# Altium schematic sheet LPC55S69_MINI.SchDoc(LPC55S69_MINI)
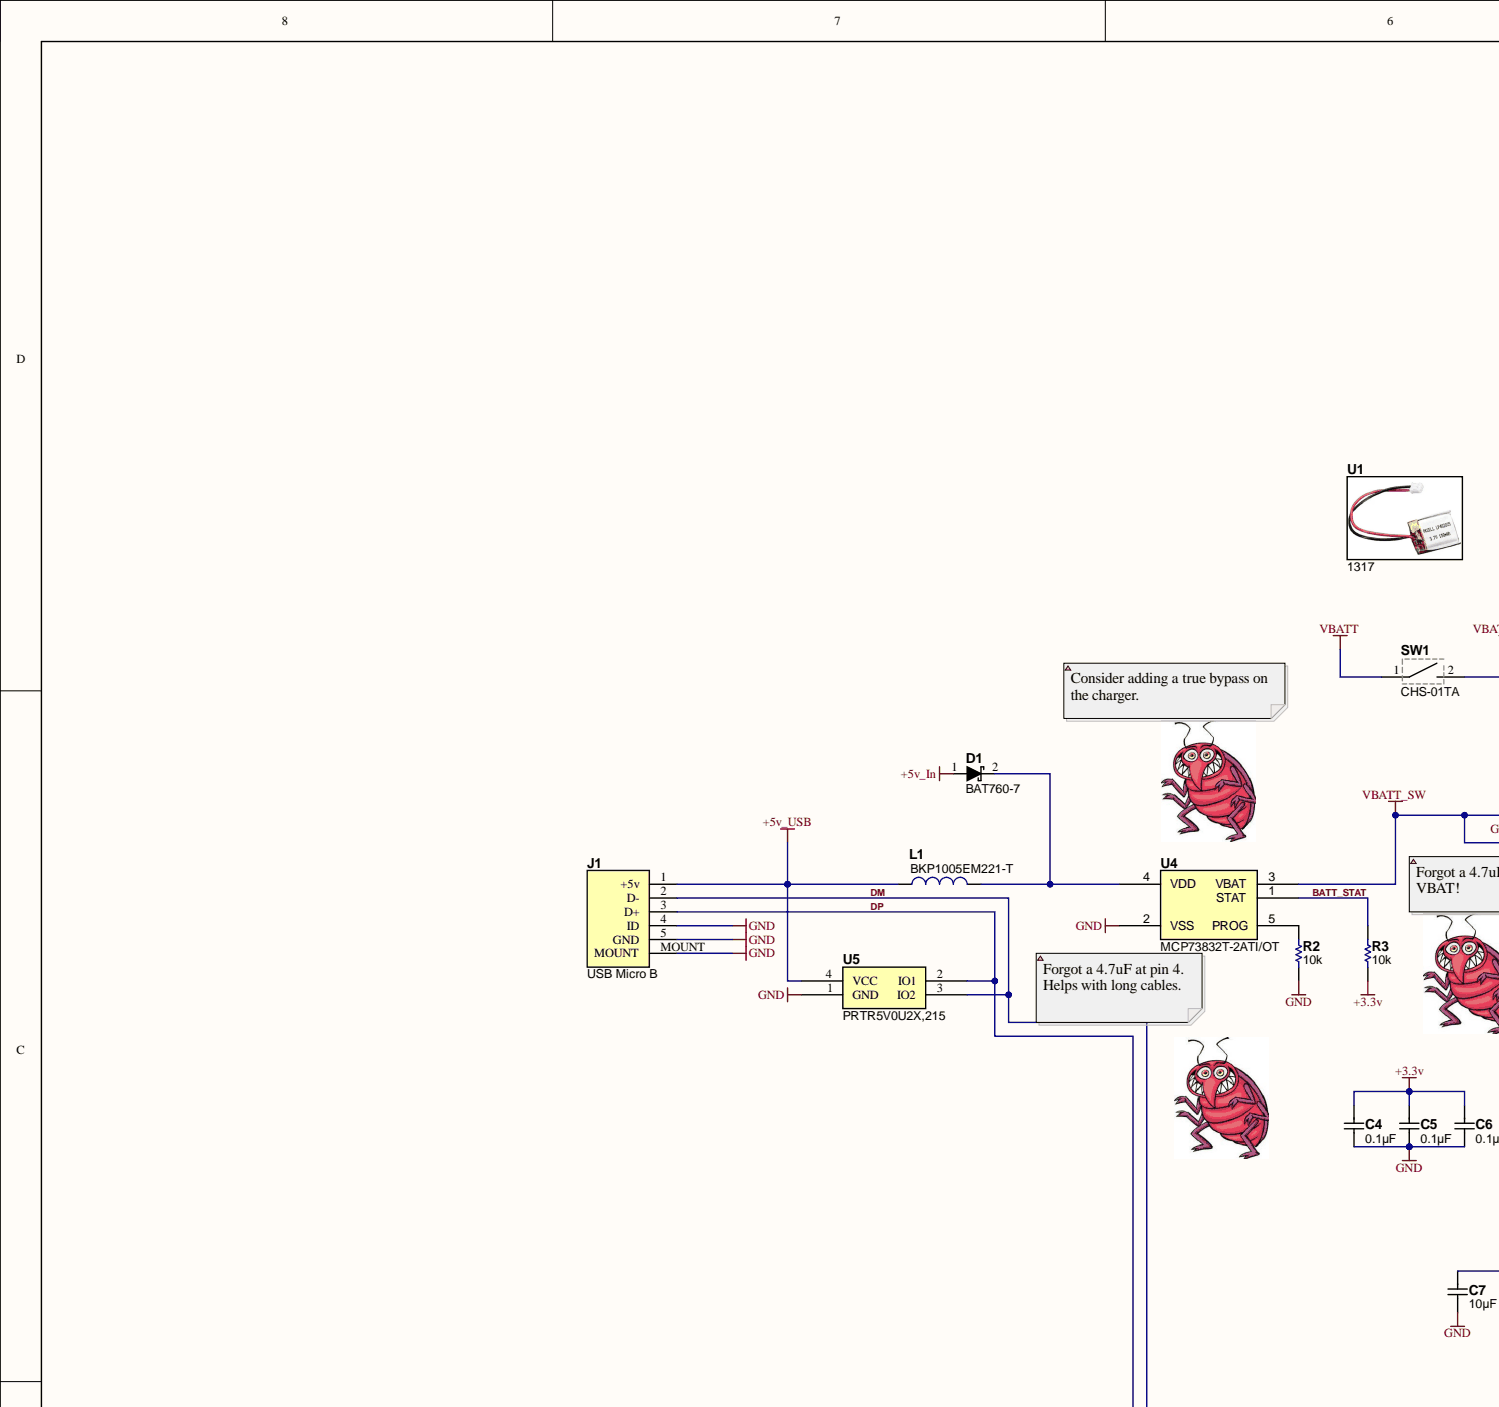
[diagram p0: LPC55S69_MINI.SchDoc, top left]
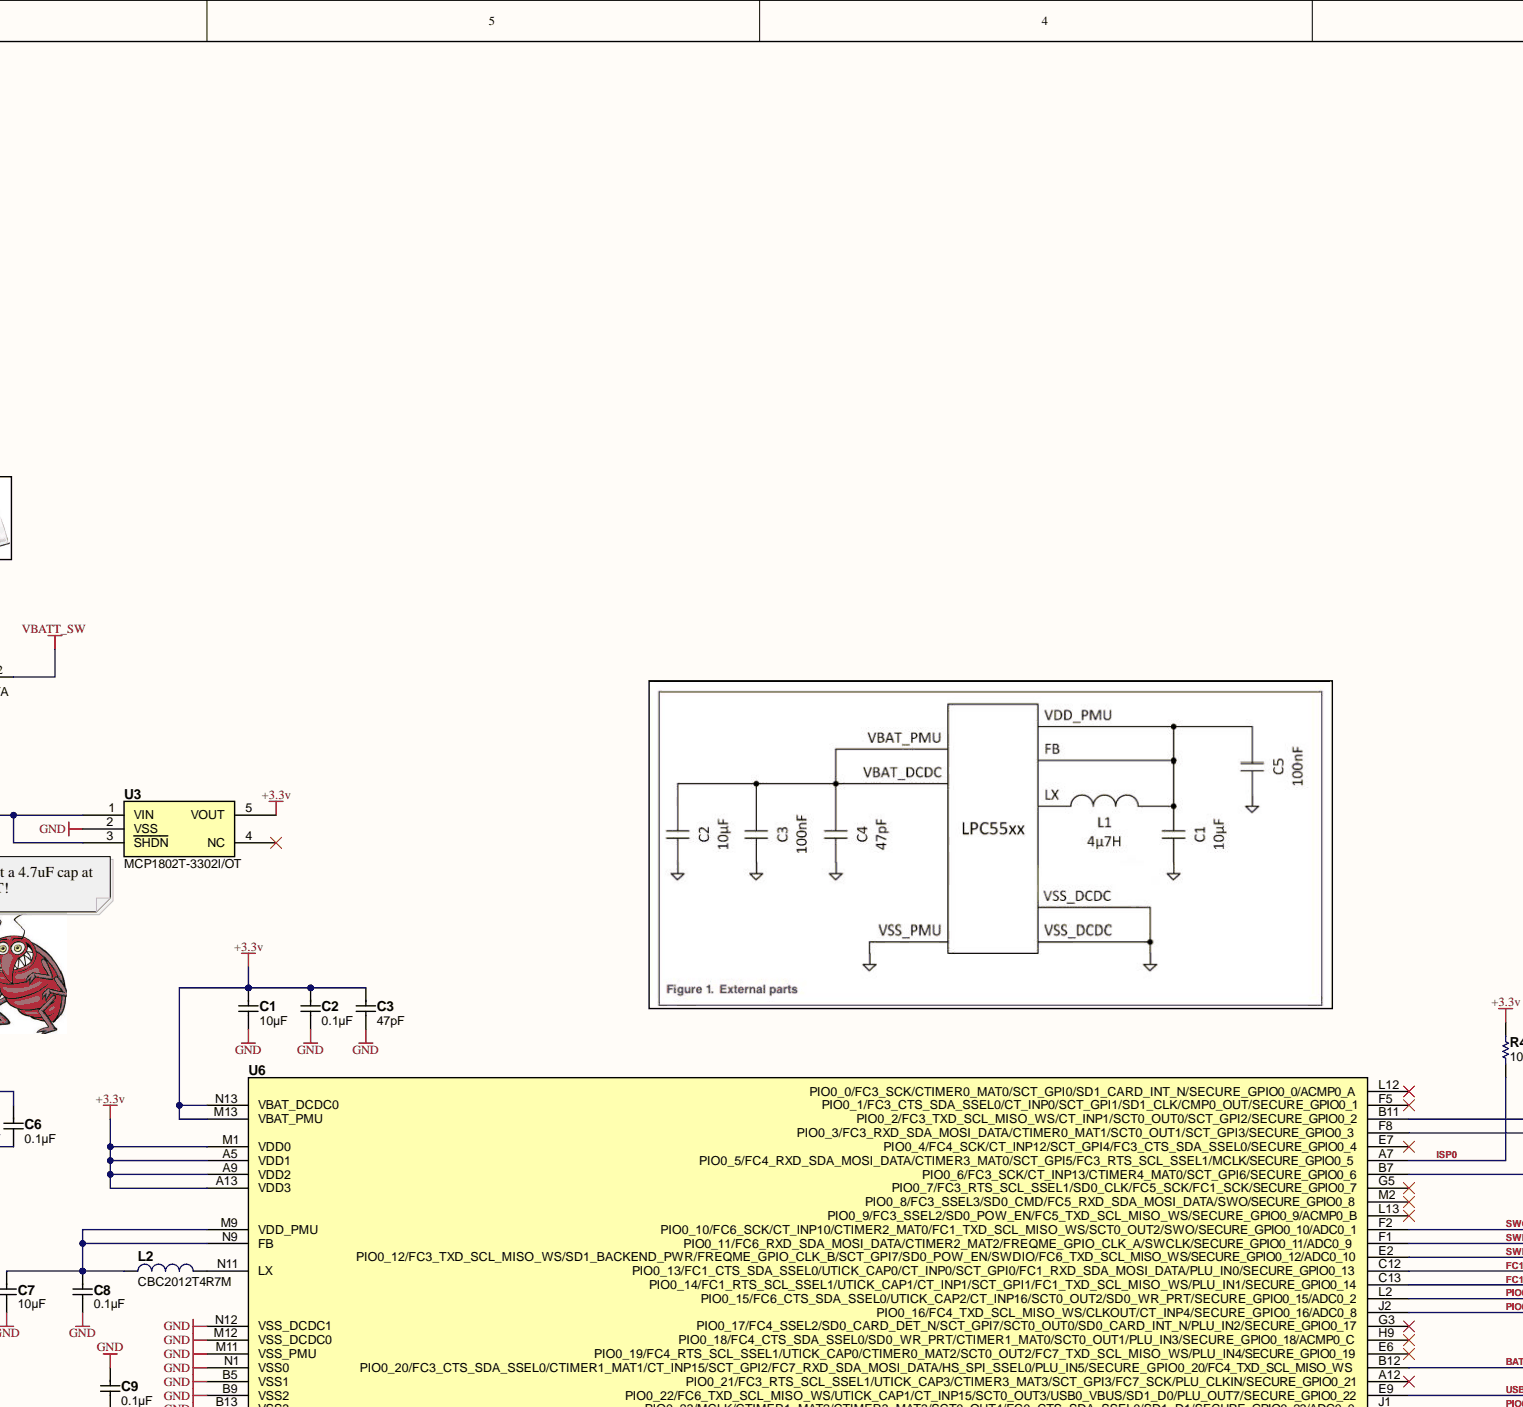
[diagram p1: LPC55S69_MINI.SchDoc, top centre]
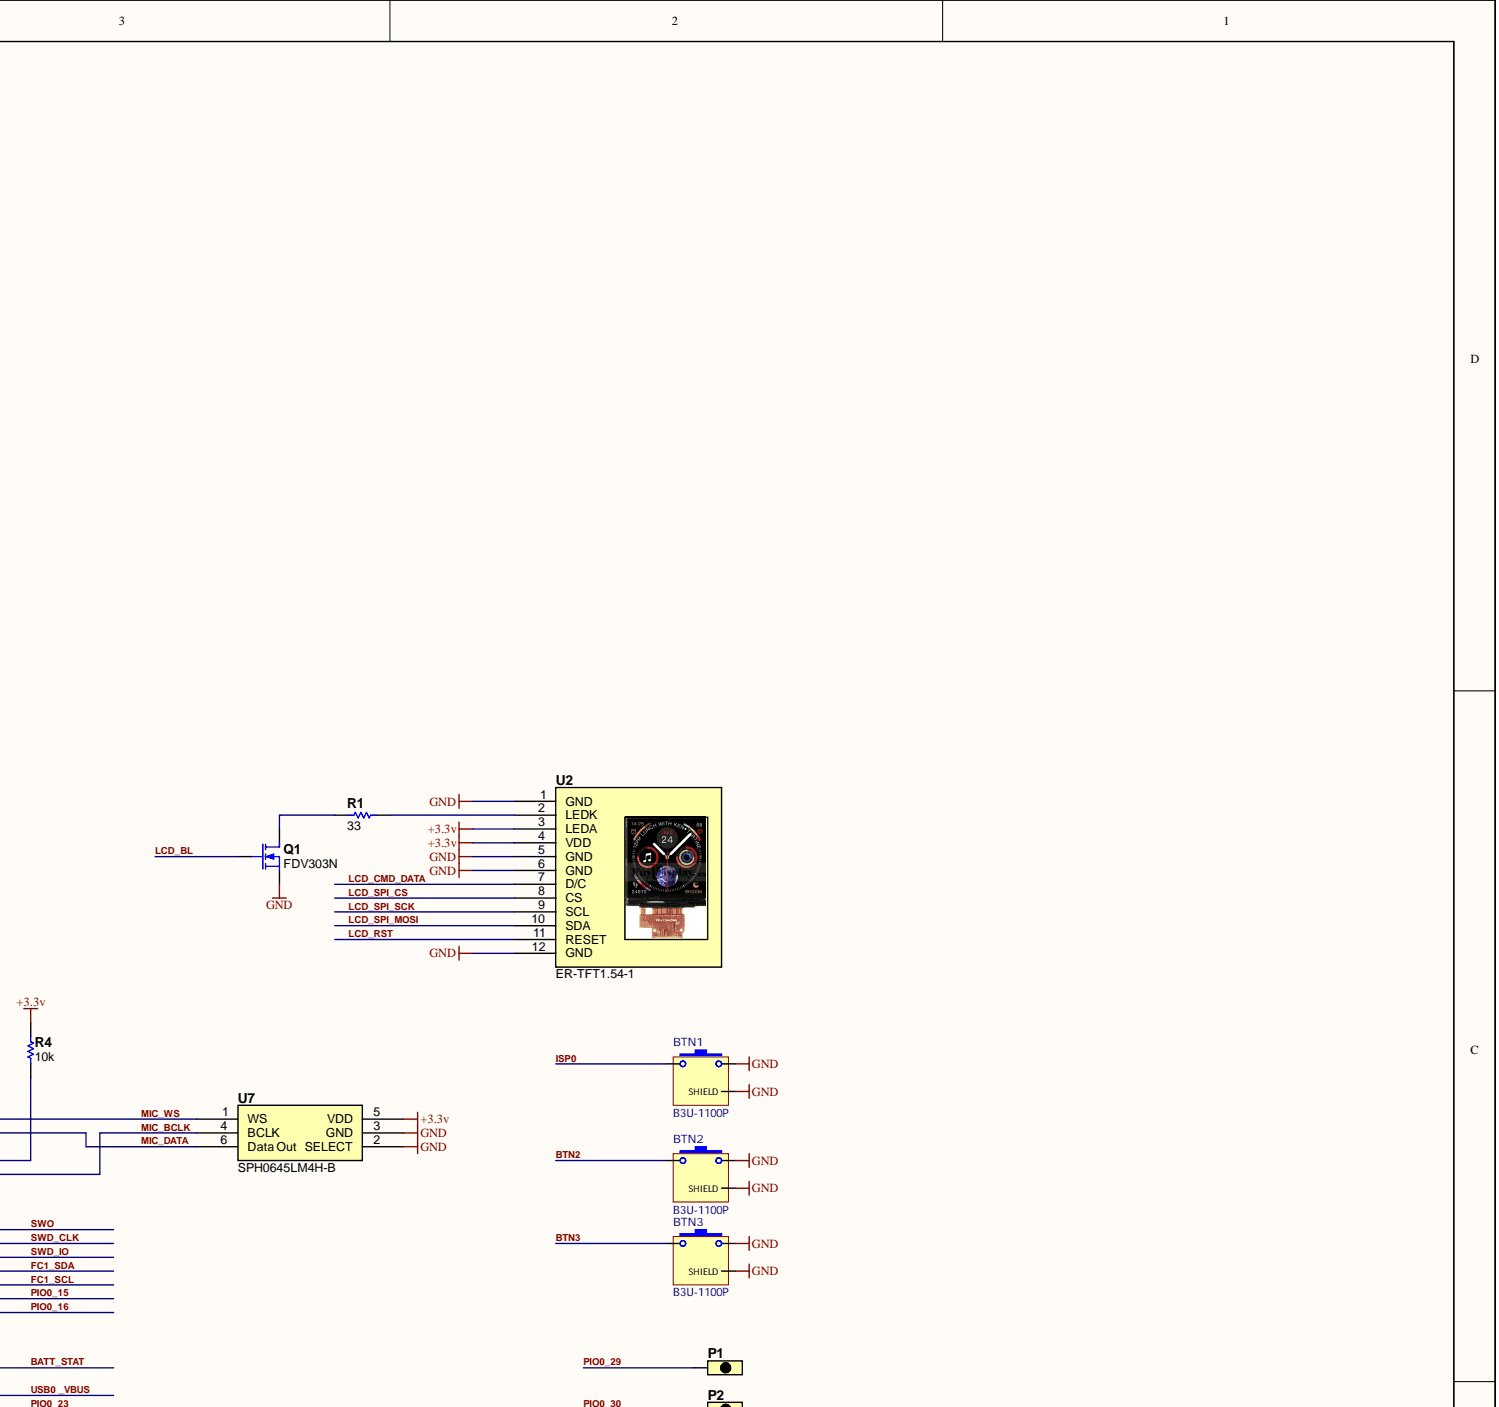
[diagram p2: LPC55S69_MINI.SchDoc, top right]
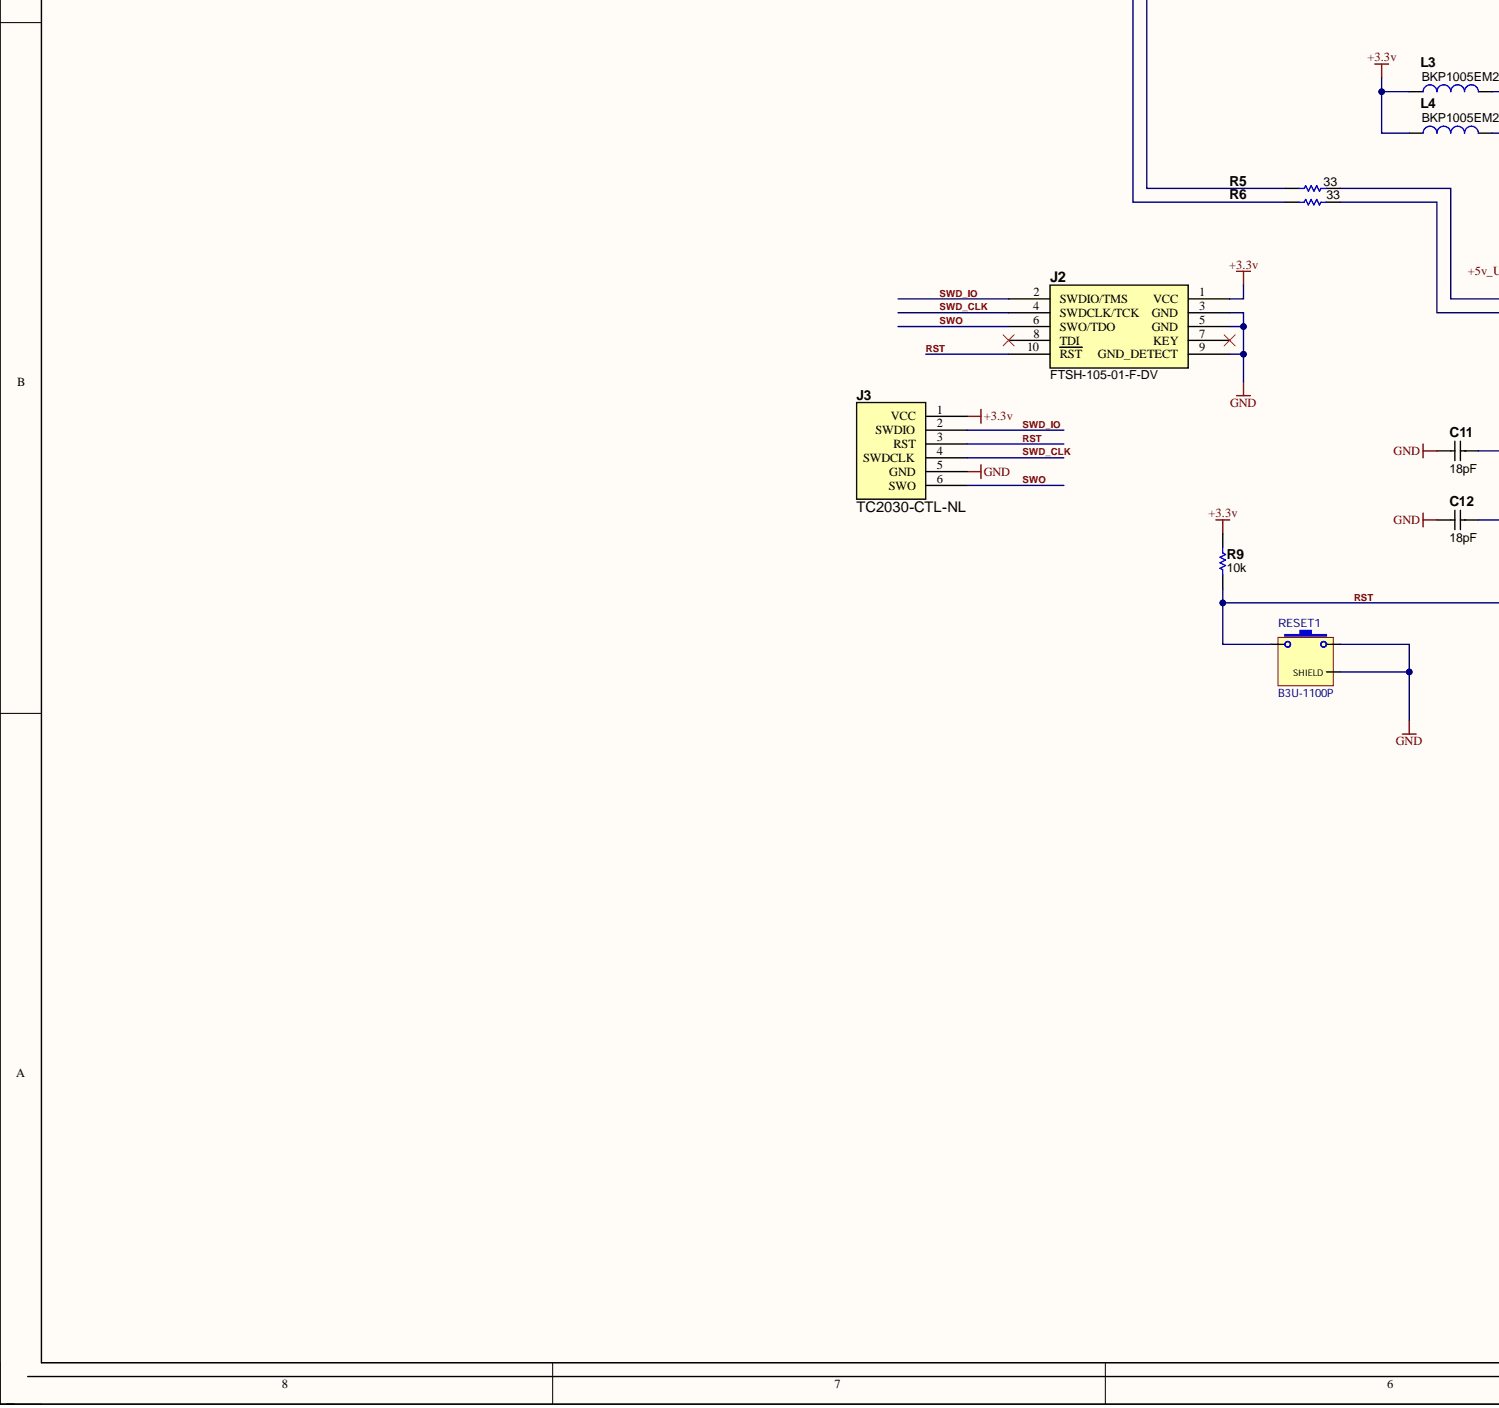
[diagram p3: LPC55S69_MINI.SchDoc, bottom left]
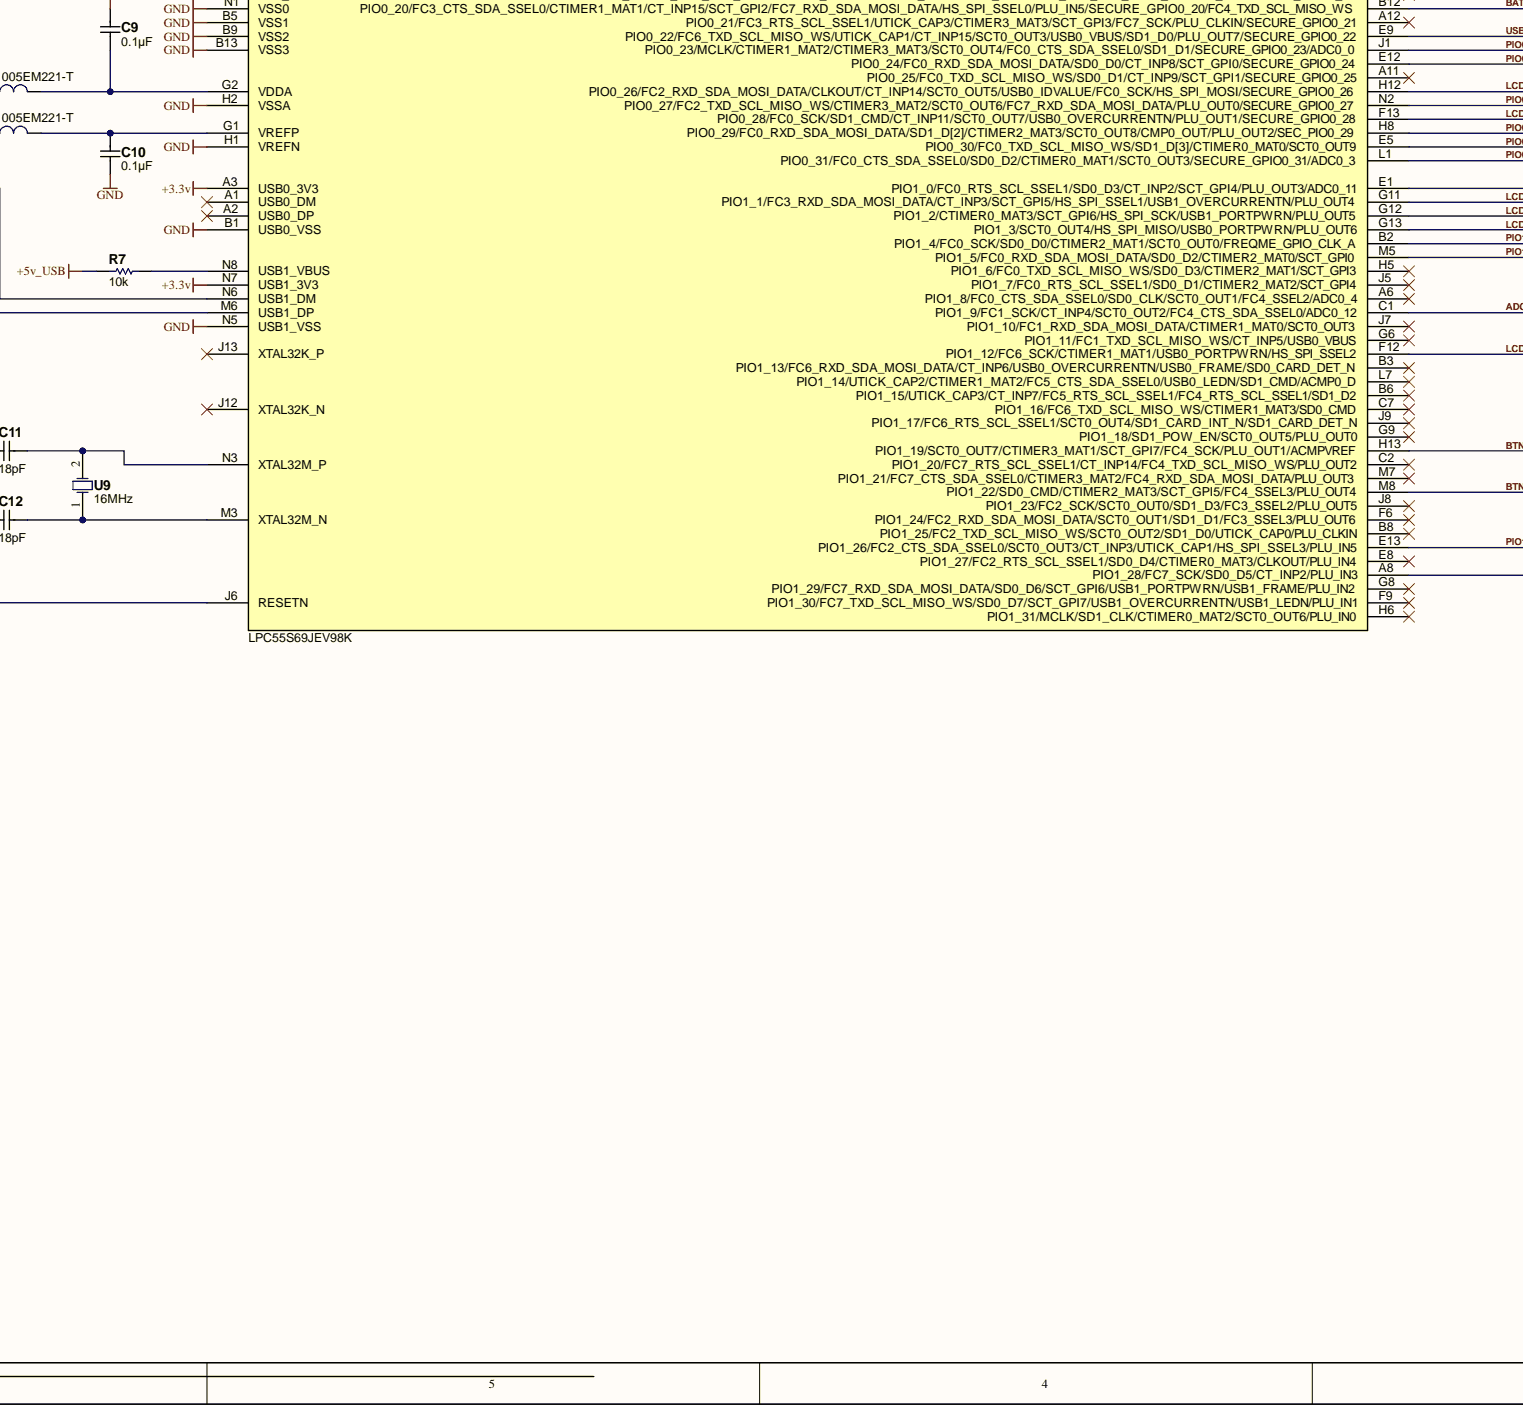
[diagram p4: LPC55S69_MINI.SchDoc, bottom centre]
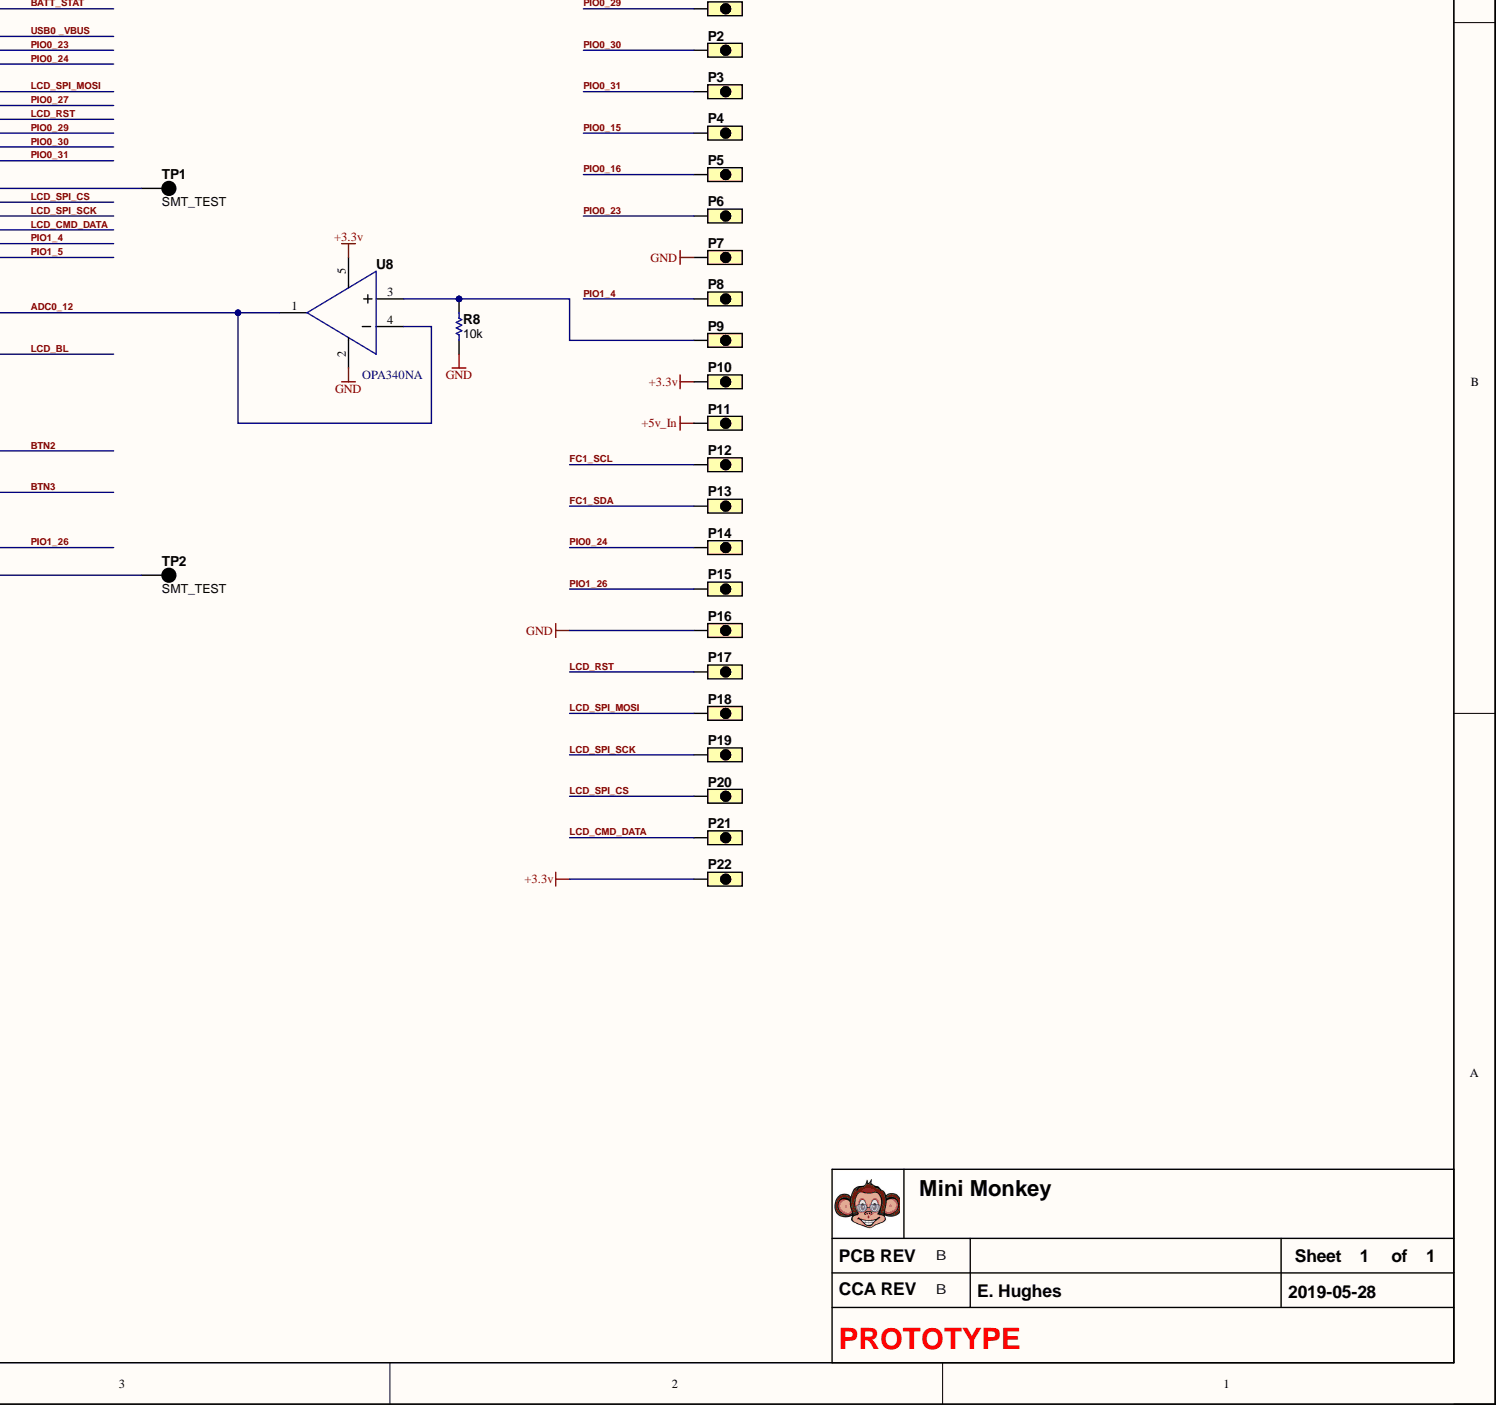
[diagram p5: LPC55S69_MINI.SchDoc, bottom right]

## Parts
BTN1  B3U-1100P  [OMRON_B3U-1100P]
BTN2  B3U-1100P  [OMRON_B3U-1100P]
BTN3  B3U-1100P  [OMRON_B3U-1100P]
C1  10µF  [C0805_1.45MM_MD]
C2  0.1µF  [C0402_0.55MM_MD]
C3  47pF  [C0402_0.55MM_MD]
C4  0.1µF  [C0402_0.55MM_MD]
C5  0.1µF  [C0402_0.55MM_MD]
C6  0.1µF  [C0402_0.55MM_MD]
C7  10µF  [C0805_1.45MM_MD]
C8  0.1µF  [C0402_0.55MM_MD]
C9  0.1µF  [C0402_0.55MM_MD]
C10  0.1µF  [C0402_0.55MM_MD]
C11  18pF  [C0402_0.55MM_MD]
C12  18pF  [C0402_0.55MM_MD]
D1  BAT760-7  [D_SOD-323_P]
J1  USB Micro B  [10103594-0001LF - No Slots]
J2  FTSH-105-01-F-DV  [FTSH-105-01-F-DV-K-A]
J3  TC2030‐CTL‐NL  [TC2030 NO LATCH]  (no BOM)
L1  BKP1005EM221-T  [C0402_HD]
L2  CBC2012T4R7M  [C0805_1.45MM_MD]
L3  BKP1005EM221-T  [C0402_HD]
L4  BKP1005EM221-T  [C0402_HD]
P1  (no value)  [CASTELLATED]  (no BOM)
P2  (no value)  [CASTELLATED]  (no BOM)
P3  (no value)  [CASTELLATED]  (no BOM)
P4  (no value)  [CASTELLATED]  (no BOM)
P5  (no value)  [CASTELLATED]  (no BOM)
P6  (no value)  [CASTELLATED]  (no BOM)
P7  (no value)  [CASTELLATED]  (no BOM)
P8  (no value)  [CASTELLATED]  (no BOM)
P9  (no value)  [CASTELLATED]  (no BOM)
P10  (no value)  [CASTELLATED]  (no BOM)
P11  (no value)  [CASTELLATED]  (no BOM)
P12  (no value)  [CASTELLATED]  (no BOM)
P13  (no value)  [CASTELLATED]  (no BOM)
P14  (no value)  [CASTELLATED]  (no BOM)
P15  (no value)  [CASTELLATED]  (no BOM)
P16  (no value)  [CASTELLATED]  (no BOM)
P17  (no value)  [CASTELLATED]  (no BOM)
P18  (no value)  [CASTELLATED]  (no BOM)
P19  (no value)  [CASTELLATED]  (no BOM)
P20  (no value)  [CASTELLATED]  (no BOM)
P21  (no value)  [CASTELLATED]  (no BOM)
P22  (no value)  [CASTELLATED]  (no BOM)
Q1  FDV303N  [SOT-23]
R1  33  [R0603_0.55MM_MD]
R2  10k  [R0402_0.40MM_MD]
R3  10k  [R0402_0.40MM_MD]
R4  10k  [R0402_0.40MM_MD]
R5  33  [R0603_0.55MM_MD]
R6  33  [R0603_0.55MM_MD]
R7  10k  [R0402_0.40MM_MD]
R8  10k  [R0402_0.40MM_MD]
R9  10k  [R0402_0.40MM_MD]
RESET1  B3U-1100P  [OMRON_B3U-1100P]
SW1  CHS-01TA  [CHS-01TA]
TP1  SMT_TEST  [SMT_TP - 25]  (no BOM)
TP2  SMT_TEST  [SMT_TP - 25]  (no BOM)
U1  1317  [LIPO-150MAH]
U2  ER-TFT1.54-1  [ER-TFT1.54-1]
U3  MCP1802T-3302I/OT  [SOT23-5]
U4  MCP73832T-2ATI/OT  [SOT23-5]
U5  PRTR5V0U2X,215  [SOT143B]
U6  LPC55S69JEV98K  [98-VFBGA]
U7  SPH0645LM4H-B  [SPH0645LM4H-B]
U8  value OPA340NA  [SOT95P245X145-5L]  (hidden comment: IC SINGLE SUPPLY OPAMP SOT-23-5)
U9  16MHz  [ABM3]

## Nets
+3.3v: C1.2 C2.2 C3.2 C4.2 C5.2 C6.2 J2.1(VCC) J3.1(VCC) L3.1 L4.1 P10.1 P22.1 R3.1 R4.2 R9.2 U2.3(LEDA) U2.4(VDD) U3.5(VOUT) U6.A3(USB0_3V3) U6.A5(VDD1) U6.A9(VDD2) U6.A13(VDD3) U6.M1(VDD0) U6.M13(VBAT_PMU) U6.N7(USB1_3V3) U6.N13(VBAT_DCDC0) U7.5(VDD) U8.5
+5v_In: D1.1 P11.1
+5v_USB: J1.1(+5v) L1.1 R7.2 U5.4(VCC)
GND: BTN1.1 BTN1.S BTN2.1 BTN2.S BTN3.1 BTN3.S C1.1 C2.1 C3.1 C4.1 C5.1 C6.1 C7.1 C8.1 C9.2 C10.1 C11.1 C12.1 J1.4(ID) J1.5(GND) J1.MOUNT J2.3(GND) J2.5(GND) J2.9(GND_DETECT) J3.5(GND) P7.1 P16.1 Q1.2 R2.1 R8.1 RESET1.1 RESET1.S U2.1(GND) U2.5(GND) U2.6(GND) U2.12(GND) U3.2(VSS) U4.2(VSS) U5.1(GND) U6.B1(USB0_VSS) U6.B5(VSS1) U6.B9(VSS2) U6.B13(VSS3) U6.H1(VREFN) U6.H2(VSSA) U6.M11(VSS_PMU) U6.M12(VSS_DCDC0) U6.N1(VSS0) U6.N5(USB1_VSS) U6.N12(VSS_DCDC1) U7.2(SELECT) U7.3(GND) U8.2
VBATT: SW1.1
VBATT_SW: SW1.2 U3.1(VIN) U3.3(SHDN) U4.3(VBAT)
ADC0_12: U6.C1(PIO1_9/FC1_SCK/CT_INP4/SCT0_OUT2/FC4_CTS_SDA_SSEL0/ADC0_12) U8.1 U8.4
BATT_STAT: R3.2 U4.1(STAT) U6.B12
BTN2: BTN2.2 U6.H13(PIO1_19/SCT0_OUT7/CTIMER3_MAT1/SCT_GPI7/FC4_SCK/PLU_OUT1/ACMPVREF)
BTN3: BTN3.2 U6.M8(PIO1_22/SD0_CMD/CTIMER2_MAT3/SCT_GPI5/FC4_SSEL3/PLU_OUT4)
DM: J1.2(D-) R5.1 U5.3(IO2)
DP: J1.3(D+) R6.1 U5.2(IO1)
FC1_SCL: P12.1 U6.C13
FC1_SDA: P13.1 U6.C12
ISP0: BTN1.2 R4.1 U6.A7
LCD_BL: Q1.1 U6.F12(PIO1_12/FC6_SCK/CTIMER1_MAT1/USB0_PORTPWRN/HS_SPI_SSEL2)
LCD_CMD_DATA: P21.1 U2.7(D/C) U6.G13(PIO1_3/SCT0_OUT4/HS_SPI_MISO/USB0_PORTPWRN/PLU_OUT6)
LCD_RST: P17.1 U2.11(RESET) U6.F13
LCD_SPI_CS: P20.1 U2.8(CS) U6.G11(PIO1_1/FC3_RXD_SDA_MOSI_DATA/CT_INP3/SCT_GPI5/HS_SPI_SSEL1/USB1_OVERCURRENTN/PLU_OUT4)
LCD_SPI_MOSI: P18.1 U2.10(SDA) U6.H12
LCD_SPI_SCK: P19.1 U2.9(SCL) U6.G12(PIO1_2/CTIMER0_MAT3/SCT_GPI6/HS_SPI_SCK/USB1_PORTPWRN/PLU_OUT5)
MIC_BCLK: U6.B7 U7.4(BCLK)
MIC_DATA: U6.F8 U7.6(Data_Out)
MIC_WS: U6.B11 U7.1(WS)
PIO0_15: P4.1 U6.L2
PIO0_16: P5.1 U6.J2
PIO0_23: P6.1 U6.J1
PIO0_24: P14.1 U6.E12
PIO0_27: U6.N2
PIO0_29: P1.1 U6.H8
PIO0_30: P2.1 U6.E5
PIO0_31: P3.1 U6.L1
PIO1_4: P8.1 U6.B2(PIO1_4/FC0_SCK/SD0_D0/CTIMER2_MAT1/SCT0_OUT0/FREQME_GPIO_CLK_A)
PIO1_5: U6.M5(PIO1_5/FC0_RXD_SDA_MOSI_DATA/SD0_D2/CTIMER2_MAT0/SCT_GPI0)
PIO1_26: P15.1 U6.E13(PIO1_26/FC2_CTS_SDA_SSEL0/SCT0_OUT3/CT_INP3/UTICK_CAP1/HS_SPI_SSEL3/PLU_IN5)
RST: J2.10(RST) J3.3(RST) R9.1 RESET1.2 U6.J6(RESETN)
SWD_CLK: J2.4(SWDCLK/TCK) J3.4(SWDCLK) U6.F1
SWD_IO: J2.2(SWDIO/TMS) J3.2(SWDIO) U6.E2
SWO: J2.6(SWO/TDO) J3.6(SWO) U6.F2
USB0 _VBUS: U6.E9
NetC7_2: C7.2 C8.2 L2.1 U6.M9(VDD_PMU) U6.N9(FB)
NetC9_1: C9.1 L3.2 U6.G2(VDDA)
NetC10_2: C10.2 L4.2 U6.G1(VREFP)
NetC11_2: C11.2 U6.N3(XTAL32M_P) U9.2
NetC12_2: C12.2 U6.M3(XTAL32M_N) U9.1
NetD1_2: D1.2 L1.2 U4.4(VDD)
NetL2_2: L2.2 U6.N11(LX)
NetP9_1: P9.1 R8.2 U8.3
NetQ1_3: Q1.3 R1.1
NetR1_2: R1.2 U2.2(LEDK)
NetR2_2: R2.2 U4.5(PROG)
NetR5_2: R5.2 U6.N6(USB1_DM)
NetR6_2: R6.2 U6.M6(USB1_DP)
NetR7_1: R7.1 U6.N8(USB1_VBUS)
NetTP1_1: TP1.1 U6.E1(PIO1_0/FC0_RTS_SCL_SSEL1/SD0_D3/CT_INP2/SCT_GPI4/PLU_OUT3/ADC0_11)
NetTP2_1: TP2.1 U6.A8(PIO1_28/FC7_SCK/SD0_D5/CT_INP2/PLU_IN3)

Not connected: J2 7(KEY) 8(TDI); U3 4(NC); U6 A1(USB0_DM) A2(USB0_DP) A6(PIO1_8/FC0_CTS_SDA_SSEL0/SD0_CLK/SCT0_OUT1/FC4_SSEL2/ADC0_4) A11 A12 B3(PIO1_13/FC6_RXD_SDA_MOSI_DATA/CT_INP6/USB0_OVERCURRENTN/USB0_FRAME/SD0_CARD_DET_N) B6(PIO1_15/UTICK_CAP3/CT_INP7/FC5_RTS_SCL_SSEL1/FC4_RTS_SCL_SSEL1/SD1_D2) B8(PIO1_25/FC2_TXD_SCL_MISO_WS/SCT0_OUT2/SD1_D0/UTICK_CAP0/PLU_CLKIN) C2(PIO1_20/FC7_RTS_SCL_SSEL1/CT_INP14/FC4_TXD_SCL_MISO_WS/PLU_OUT2) C7(PIO1_16/FC6_TXD_SCL_MISO_WS/CTIMER1_MAT3/SD0_CMD) E6 E7 E8(PIO1_27/FC2_RTS_SCL_SSEL1/SD0_D4/CTIMER0_MAT3/CLKOUT/PLU_IN4) F5 F6(PIO1_24/FC2_RXD_SDA_MOSI_DATA/SCT0_OUT1/SD1_D1/FC3_SSEL3/PLU_OUT6) F9(PIO1_30/FC7_TXD_SCL_MISO_WS/SD0_D7/SCT_GPI7/USB1_OVERCURRENTN/USB1_LEDN/PLU_IN1) G3 G5 G6(PIO1_11/FC1_TXD_SCL_MISO_WS/CT_INP5/USB0_VBUS) G8(PIO1_29/FC7_RXD_SDA_MOSI_DATA/SD0_D6/SCT_GPI6/USB1_PORTPWRN/USB1_FRAME/PLU_IN2) G9(PIO1_18/SD1_POW_EN/SCT0_OUT5/PLU_OUT0) H5(PIO1_6/FC0_TXD_SCL_MISO_WS/SD0_D3/CTIMER2_MAT1/SCT_GPI3) H6(PIO1_31/MCLK/SD1_CLK/CTIMER0_MAT2/SCT0_OUT6/PLU_IN0) H9 J5(PIO1_7/FC0_RTS_SCL_SSEL1/SD0_D1/CTIMER2_MAT2/SCT_GPI4) J7(PIO1_10/FC1_RXD_SDA_MOSI_DATA/CTIMER1_MAT0/SCT0_OUT3) J8(PIO1_23/FC2_SCK/SCT0_OUT0/SD1_D3/FC3_SSEL2/PLU_OUT5) J9(PIO1_17/FC6_RTS_SCL_SSEL1/SCT0_OUT4/SD1_CARD_INT_N/SD1_CARD_DET_N) J12(XTAL32K_N) J13(XTAL32K_P) L7(PIO1_14/UTICK_CAP2/CTIMER1_MAT2/FC5_CTS_SDA_SSEL0/USB0_LEDN/SD1_CMD/ACMP0_D) L12 L13 M2 M7(PIO1_21/FC7_CTS_SDA_SSEL0/CTIMER3_MAT2/FC4_RXD_SDA_MOSI_DATA/PLU_OUT3)
